# Altium schematic sheet ESP32_Relay_X4_Modbus(303E32DC411).SchDoc
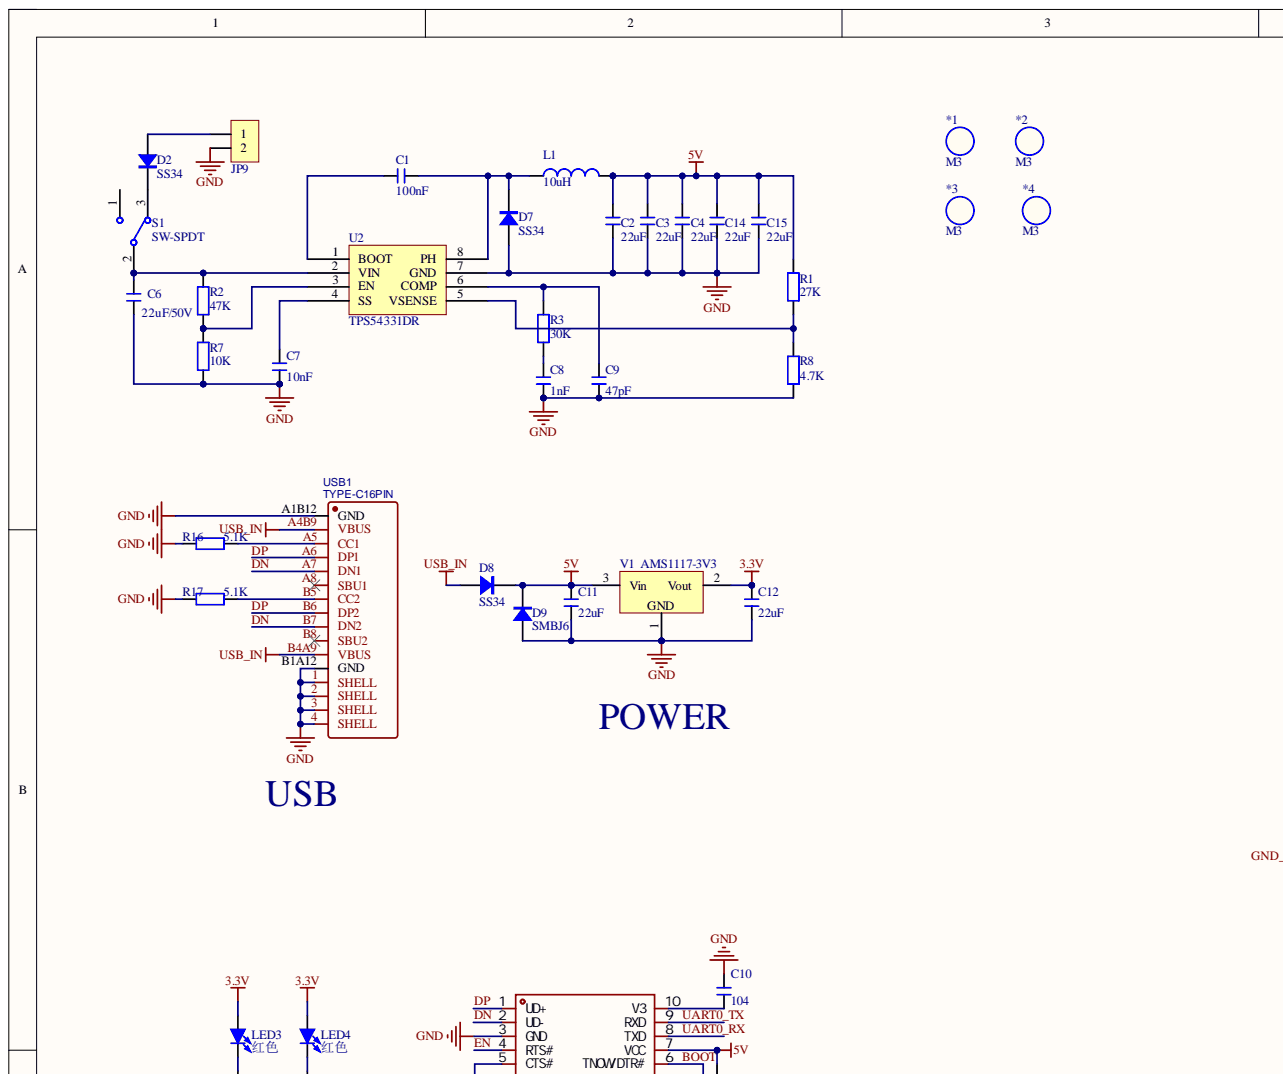
[diagram p0: ESP32_Relay_X4_Modbus(303E32DC411).SchDoc, top left]
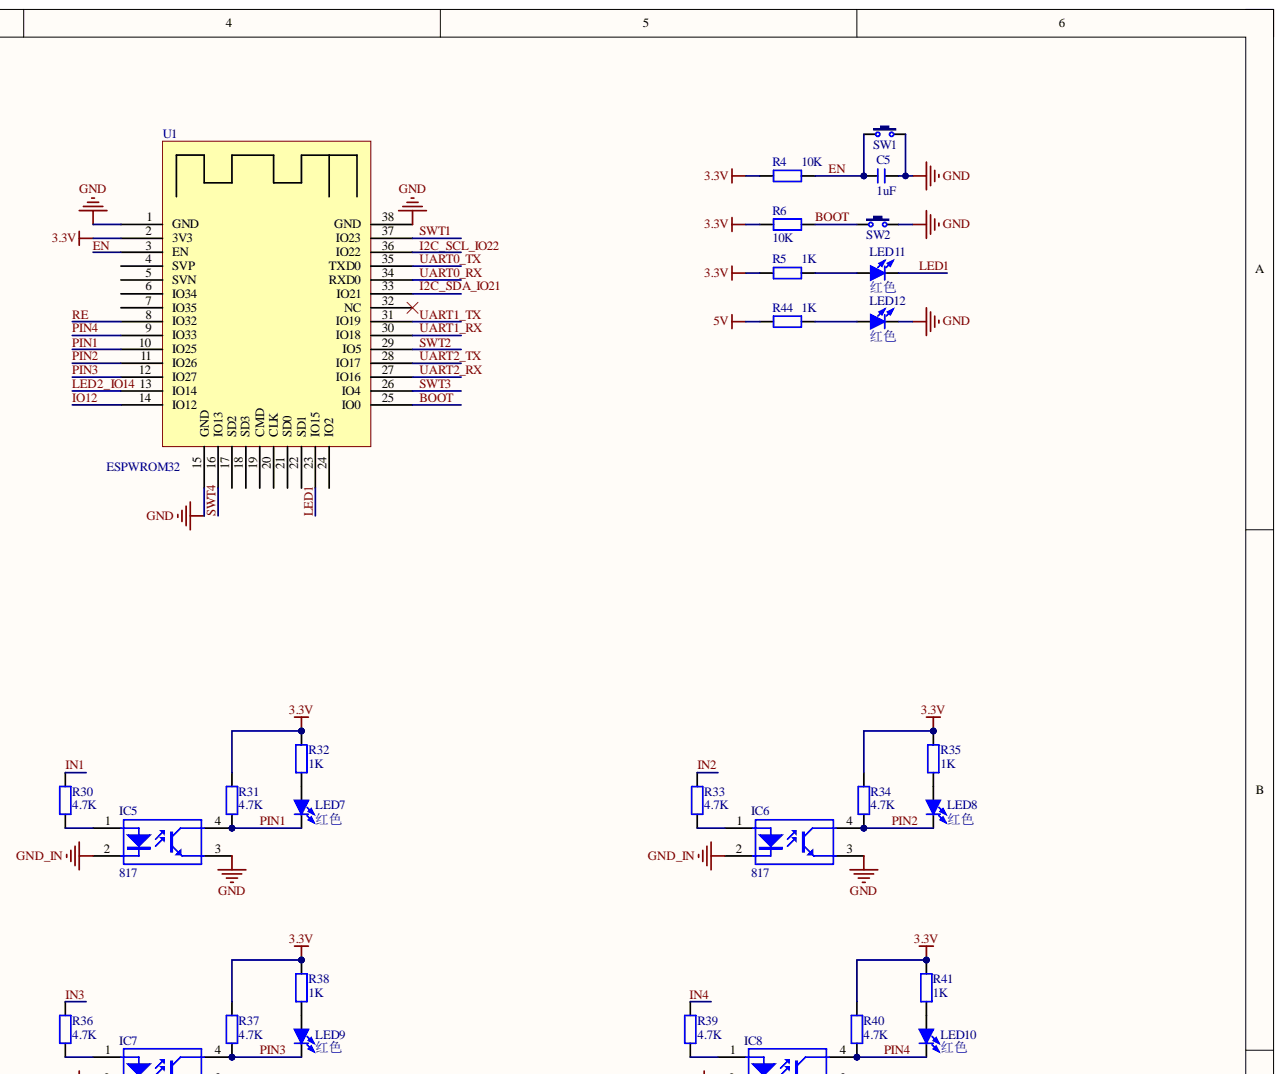
[diagram p1: ESP32_Relay_X4_Modbus(303E32DC411).SchDoc, top right]
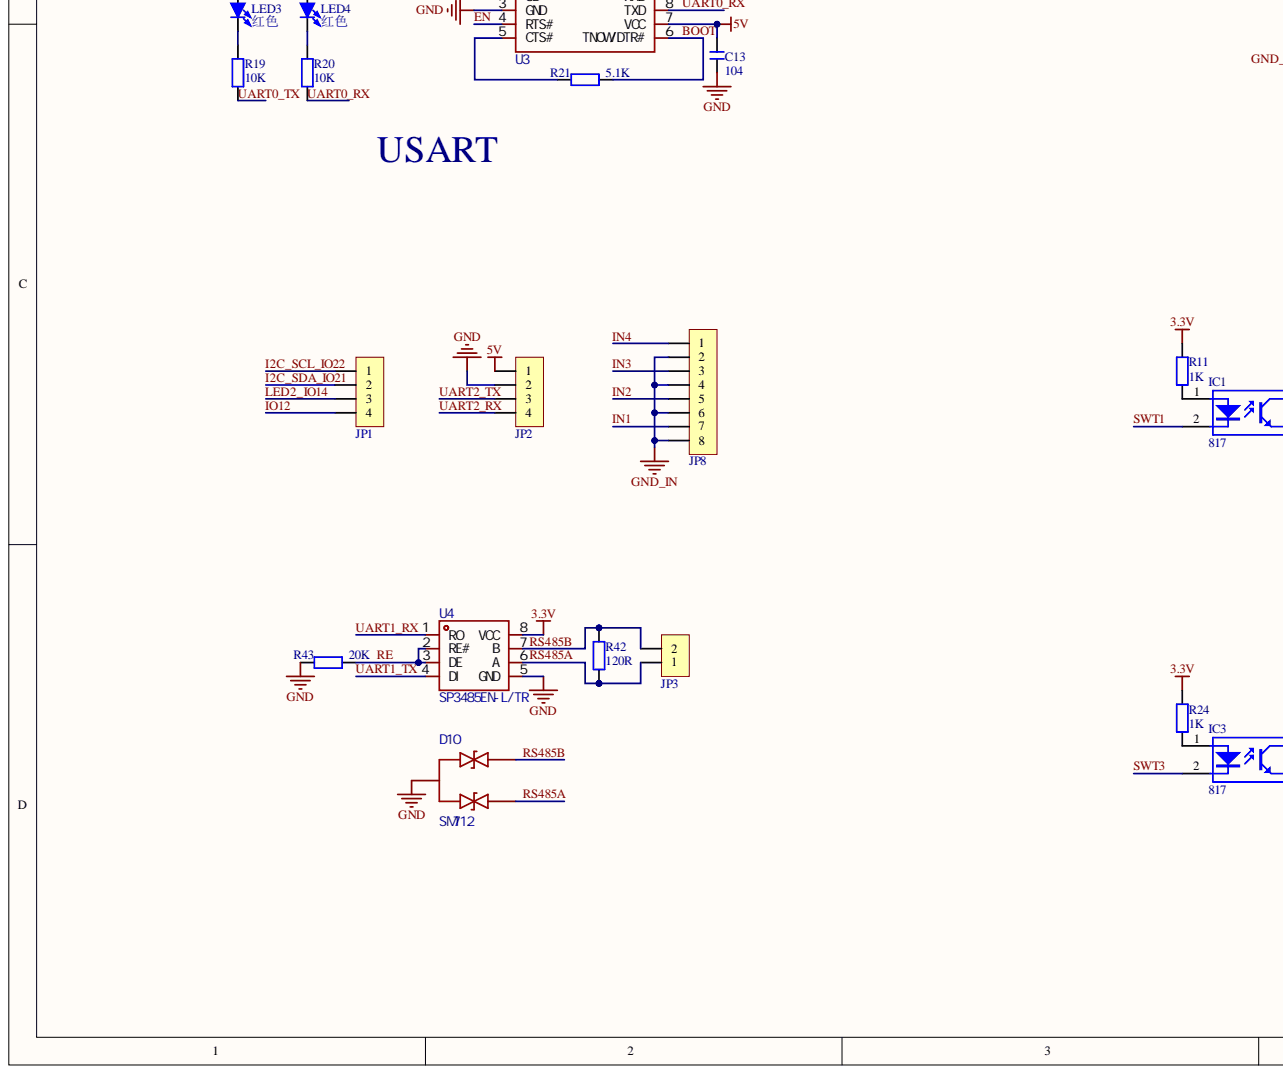
[diagram p2: ESP32_Relay_X4_Modbus(303E32DC411).SchDoc, bottom left]
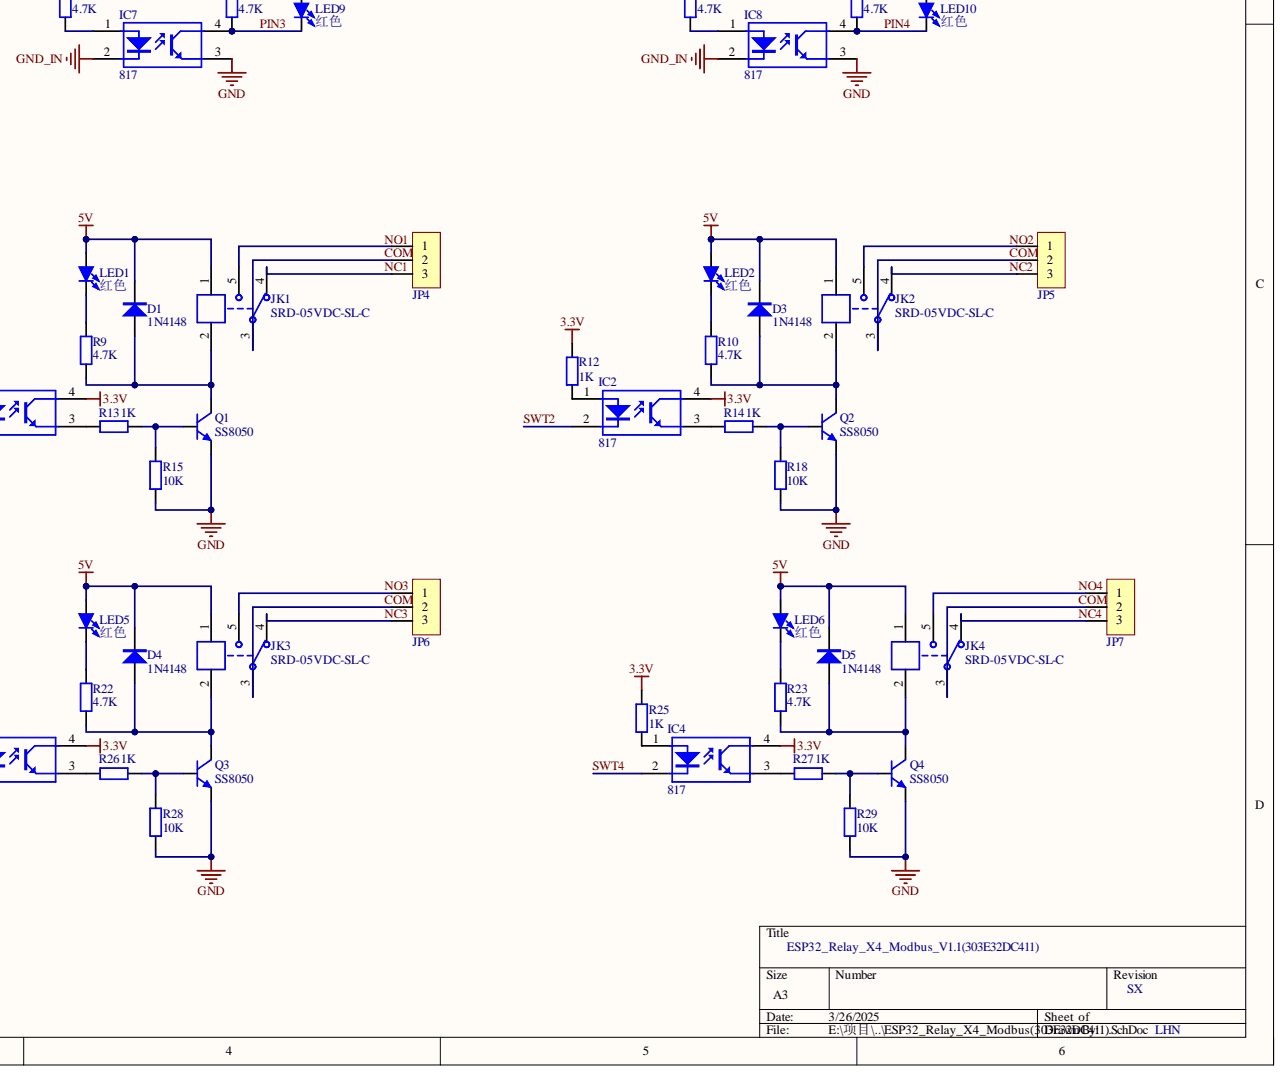
[diagram p3: ESP32_Relay_X4_Modbus(303E32DC411).SchDoc, bottom right]

## Parts
*1  M3  [M3 125X200]
*2  M3  [M3 125X200]
*3  M3  [M3 125X200]
*4  M3  [M3 125X200]
C1  100nF  [C 0603_L]
C2  22uF  [C 0805_L]
C3  22uF  [C 0805_L]
C4  22uF  [C 0805_L]
C5  1uF  [C 0603_L]
C6  22uF/50V  [C 0805_L]
C7  10nF  [C 0603_L]
C8  1nF  [C 0603_L]
C9  47pF  [C 0603_L]
C10  104  [C 0603_L]
C11  22uF  [C 0805_L]
C12  22uF  [C 0805_L]
C13  104  [C 0603_L]
C14  22uF  [C 0805_L]
C15  22uF  [C 0805_L]
D1  1N4148  [MINI_MELF (LL34)]
D2  SS34  [SMA]
D3  1N4148  [MINI_MELF (LL34)]
D4  1N4148  [MINI_MELF (LL34)]
D5  1N4148  [MINI_MELF (LL34)]
D7  SS34  [SMA]
D8  SS34  [SMA]
D9  SMBJ6  [SMA]
D10  SM712  [SOT-23-3_L2.9-W1.3-P1.90-LS2.4-BR]
IC1  817  [SOP4-W2.54_N]
IC2  817  [SOP4-W2.54_N]
IC3  817  [SOP4-W2.54_N]
IC4  817  [SOP4-W2.54_N]
IC5  817  [SOP4-W2.54_N]
IC6  817  [SOP4-W2.54_N]
IC7  817  [SOP4-W2.54_N]
IC8  817  [SOP4-W2.54_N]
JK1  SRD-05VDC-SL-C  [SRD-C_B]
JK2  SRD-05VDC-SL-C  [SRD-C_B]
JK3  SRD-05VDC-SL-C  [SRD-C_B]
JK4  SRD-05VDC-SL-C  [SRD-C_B]
JP1  HDR-1X4  [HDR2.54-LI-4P]  (value not drawn)
JP2  HDR-1X4  [HDR2.54-LI-4P]  (value not drawn)
JP3  HDR-1X2  [KF128-3.81-2P]  (value not drawn)
JP4  HDR-1X3  [KF128-3.81-3P]  (value not drawn)
JP5  HDR-1X3  [KF128-3.81-3P]  (value not drawn)
JP6  HDR-1X3  [KF128-3.81-3P]  (value not drawn)
JP7  HDR-1X3  [KF128-3.81-3P]  (value not drawn)
JP8  HDR-1X8  [KF128-3.81-8P]  (value not drawn)
JP9  HDR-1X2  [KF128-3.81-2P]  (value not drawn)
L1  value 10uH  [IND-SMD_L7.1-W6.6]  (hidden comment: MWSA0603S-100MT)
LED1  红色  [LED 0603R]
LED2  红色  [LED 0603R]
LED3  红色  [LED 0603R]
LED4  红色  [LED 0603R]
LED5  红色  [LED 0603R]
LED6  红色  [LED 0603R]
LED7  红色  [LED 0603R]
LED8  红色  [LED 0603R]
LED9  红色  [LED 0603R]
LED10  红色  [LED 0603R]
LED11  红色  [LED 0603R]
LED12  红色  [LED 0603R]
Q1  SS8050  [SOT23-3N]
Q2  SS8050  [SOT23-3N]
Q3  SS8050  [SOT23-3N]
Q4  SS8050  [SOT23-3N]
R1  27K  [R 0603_L]
R2  47K  [R 0603_L]
R3  30K  [R 0603_L]
R4  10K  [R 0603_L]
R5  1K  [R 0603_L]
R6  10K  [R 0603_L]
R7  10K  [R 0603_L]
R8  4.7K  [R 0603_L]
R9  4.7K  [R 0603_L]
R10  4.7K  [R 0603_L]
R11  1K  [R 0603_L]
R12  1K  [R 0603_L]
R13  1K  [R 0603_L]  (value not drawn)
R14  1K  [R 0603_L]  (value not drawn)
R15  10K  [R 0603_L]
R16  5.1K  [R 0603_L]
R17  5.1K  [R 0603_L]
R18  10K  [R 0603_L]
R19  10K  [R 0603_L]
R20  10K  [R 0603_L]
R21  5.1K  [R 0603_L]
R22  4.7K  [R 0603_L]
R23  4.7K  [R 0603_L]
R24  1K  [R 0603_L]
R25  1K  [R 0603_L]
R26  1K  [R 0603_L]  (value not drawn)
R27  1K  [R 0603_L]  (value not drawn)
R28  10K  [R 0603_L]
R29  10K  [R 0603_L]
R30  4.7K  [R 0603_L]
R31  4.7K  [R 0603_L]
R32  1K  [R 0603_L]
R33  4.7K  [R 0603_L]
R34  4.7K  [R 0603_L]
R35  1K  [R 0603_L]
R36  4.7K  [R 0603_L]
R37  4.7K  [R 0603_L]
R38  1K  [R 0603_L]
R39  4.7K  [R 0603_L]
R40  4.7K  [R 0603_L]
R41  1K  [R 0603_L]
R42  120R  [R 0603_L]
R43  20K  [R 0603_L]
R44  1K  [R 0603_L]
S1  SW-SPDT  [sw]
SW1  3x6x5  [SW-SMD_L3.9-W3.0-P4.45]  (value not drawn)
SW2  3x6x5  [SW-SMD_L3.9-W3.0-P4.45]  (value not drawn)
U1  ESPWROM32  [ESP32]
U2  TPS54331DR  [SOIC-8_150mil]
U3  CH340X  [MSOP-10_L3.0-W3.0-P0.50-LS5.0-BL]  (value not drawn)
U4  SP3485EN-L/TR  Supply Voltage: 3.3V  [SOIC-8_L5.0-W4.0-P1.27-LS6.0-BL]
USB1  TYPE-C16PIN  [USB-C-SMD_TYPE-C16PIN]
V1  AMS1117-3V3  [SOT223_N]

## Nets
3.3V: C12.1 IC1.4 IC2.4 IC3.4 IC4.4 LED3.1 LED4.1 R4.1 R5.1 R6.2 R11.1 R12.1 R24.1 R25.1 R31.1 R32.1 R34.1 R35.1 R37.1 R38.1 R40.1 R41.1 U1.2(3V3) U4.8(VCC) V1.2
5V: C2.2 C3.2 C4.2 C11.1 C13.2 C14.2 C15.2 D1.2 D3.2 D4.2 D5.2 D8.2 D9.2 JK1.1 JK2.1 JK3.1 JK4.1 JP2.1 L1.2 LED1.1 LED2.1 LED5.1 LED6.1 R1.2 R44.1 U3.7(VCC) V1.3
GND: C2.1 C3.1 C4.1 C5.1 C6.1 C7.2 C8.2 C9.2 C10.1 C11.2 C12.2 C13.1 C14.1 C15.1 D7.1 D9.1 D10.3 IC5.3 IC6.3 IC7.3 IC8.3 JP2.2 JP9.2 LED12.2 Q1.2 Q2.2 Q3.2 Q4.2 R7.1 R8.1 R15.2 R16.1 R17.1 R18.2 R28.2 R29.2 R43.2 SW1.2 SW2.2 U1.1(GND) U1.15(GND) U1.38(GND) U2.7(GND) U3.3(GND) U4.5(GND) USB1.1(SHELL) USB1.2(SHELL) USB1.3(SHELL) USB1.4(SHELL) USB1.A1B12(GND) USB1.B1A12(GND) V1.1
GND_IN: IC5.2 IC6.2 IC7.2 IC8.2 JP8.2 JP8.4 JP8.6 JP8.8
USB_IN: D8.1 USB1.A4B9(VBUS) USB1.B4A9(VBUS)
BOOT: R6.1 R21.1 SW2.1 U1.25(IO0) U3.6(TNOW/DTR#)
COM1: JK1.3 JP4.2
COM2: JK2.3 JP5.2
COM3: JK3.3 JP6.2
COM4: JK4.3 JP7.2
DN: U3.2(UD-) USB1.A7(DN1) USB1.B7(DN2)
DP: U3.1(UD+) USB1.A6(DP1) USB1.B6(DP2)
EN: C5.2 R4.2 SW1.1 U1.3(EN) U3.4(RTS#)
I2C_SCL_IO22: JP1.1 U1.36(IO22)
I2C_SDA_IO21: JP1.2 U1.33(IO21)
IN1: JP8.7 R30.1
IN2: JP8.5 R33.1
IN3: JP8.3 R36.1
IN4: JP8.1 R39.1
IO12: JP1.4 U1.14(IO12)
LED1: LED11.2 U1.23(IO15)
LED2_IO14: JP1.3 U1.13(IO14)
NC1: JK1.4 JP4.3
NC2: JK2.4 JP5.3
NC3: JK3.4 JP6.3
NC4: JK4.4 JP7.3
NO1: JK1.5 JP4.1
NO2: JK2.5 JP5.1
NO3: JK3.5 JP6.1
NO4: JK4.5 JP7.1
PIN1: IC5.4 LED7.2 R31.2 U1.10(IO25)
PIN2: IC6.4 LED8.2 R34.2 U1.11(IO26)
PIN3: IC7.4 LED9.2 R37.2 U1.12(IO27)
PIN4: IC8.4 LED10.2 R40.2 U1.9(IO33)
RE: R43.1 U1.8(IO32) U4.2(RE#) U4.3(DE)
RS485A: D10.1 JP3.1 R42.2 U4.6(A)
RS485B: D10.2 JP3.2 R42.1 U4.7(B)
SWT1: IC1.2 U1.37(IO23)
SWT2: IC2.2 U1.29(IO5)
SWT3: IC3.2 U1.26(IO4)
SWT4: IC4.2 U1.16(IO13)
UART0_RX: R20.1 U1.34(RXD0) U3.8(TXD)
UART0_TX: R19.1 U1.35(TXD0) U3.9(RXD)
UART1_RX: U1.30(IO18) U4.1(RO)
UART1_TX: U1.31(IO19) U4.4(DI)
UART2_RX: JP2.4 U1.27(IO16)
UART2_TX: JP2.3 U1.28(IO17)
NetC1_1: C1.1 U2.1(BOOT)
NetC1_2: C1.2 D7.2 L1.1 U2.8(PH)
NetC6_2: C6.2 R2.2 S1.2 U2.2(VIN)
NetC7_1: C7.1 U2.4(SS)
NetC8_1: C8.1 R3.1
NetC9_1: C9.1 R3.2 U2.6(COMP)
NetC10_2: C10.2 U3.10(V3)
NetD1_1: D1.1 JK1.2 Q1.3 R9.2
NetD2_1: D2.1 JP9.1
NetD2_2: D2.2 S1.3
NetD3_1: D3.1 JK2.2 Q2.3 R10.2
NetD4_1: D4.1 JK3.2 Q3.3 R22.2
NetD5_1: D5.1 JK4.2 Q4.3 R23.2
NetIC1_1: IC1.1 R11.2
NetIC1_3: IC1.3 R13.1
NetIC2_1: IC2.1 R12.2
NetIC2_3: IC2.3 R14.1
NetIC3_1: IC3.1 R24.2
NetIC3_3: IC3.3 R26.1
NetIC4_1: IC4.1 R25.2
NetIC4_3: IC4.3 R27.1
NetIC5_1: IC5.1 R30.2
NetIC6_1: IC6.1 R33.2
NetIC7_1: IC7.1 R36.2
NetIC8_1: IC8.1 R39.2
NetLED1_2: LED1.2 R9.1
NetLED2_2: LED2.2 R10.1
NetLED3_2: LED3.2 R19.2
NetLED4_2: LED4.2 R20.2
NetLED5_2: LED5.2 R22.1
NetLED6_2: LED6.2 R23.1
NetLED7_1: LED7.1 R32.2
NetLED8_1: LED8.1 R35.2
NetLED9_1: LED9.1 R38.2
NetLED10_1: LED10.1 R41.2
NetLED11_1: LED11.1 R5.2
NetLED12_1: LED12.1 R44.2
NetQ1_1: Q1.1 R13.2 R15.1
NetQ2_1: Q2.1 R14.2 R18.1
NetQ3_1: Q3.1 R26.2 R28.1
NetQ4_1: Q4.1 R27.2 R29.1
NetR1_1: R1.1 R8.2 U2.5(VSENSE)
NetR2_1: R2.1 R7.2 U2.3(EN)
NetR16_2: R16.2 USB1.A5(CC1)
NetR17_2: R17.2 USB1.B5(CC2)
NetR21_2: R21.2 U3.5(CTS#)

Not connected: S1 1; U1 4(SVP) 5(SVN) 6(IO34) 7(IO35) 17(SD2) 18(SD3) 19(CMD) 20(CLK) 21(SD0) 22(SD1) 24(IO2) 32(NC); USB1 A8(SBU1) B8(SBU2)

## Library data of the parts
*1-*4 = M3: 铜柱孔; 版权归属: 源创客; BOM名称: 铜柱孔; Component Kind: Standard; Library Name: 15.1 - 未分类器件.SchLib; 学习资源: www.ooocke.com
C1-C15 = C: 无极性贴片电容; 材质: X7R; 版权归属: 源创客; BOM名称: 无极性贴片电容; Component Kind: Standard; Library Name: 3.1   - 电阻-电容-电感.SchLib; 学习资源: www.ooocke.com
D1 D3-D5 = 1N4148: 高速开关二极管; 版权归属: 源创客; BOM名称: 高速开关二极管; Component Kind: Standard; Library Name: 8.1   - 二极管-整流桥.SchLib; 学习资源: www.ooocke.com
D2 D7-D9 = SS24: 肖特基二极管; 属性: 40V/2A; 版权归属: 源创客; BOM名称: 肖特基二极管; Component Kind: Standard; Library Name: 8.1   - 二极管-整流桥.SchLib; 学习资源: www.ooocke.com
D10 = SM712_C521963: Manufacturer: TECH PUBLIC(台舟); Add into BOM: yes; Breakdown Voltage: 7.5V；13.3V; level of protection: IEC 61000-4-2; Maximum Clamping Voltage: 15V；25V; Number of Lines: 双路; Peak Pulse Current (Ipp): 7A; Peak Pulse Power Dissipation (Ppp): 150W; polarity: 双向; Reverse Leakage Current (Ir): 500nA；10nA; Reverse Stand-Off Voltage (Vrwm): 12V；7V; Type: ESD; Component Kind: Standard; Convert to PCB: yes; Datasheet: https://atta.szlcsc.com/upload/public/pdf/source/20210810/E76C7C1824B0CB2A5B8963B3ABCE2DCE.pdf; Device: SM712_C521963; JLCPCB Part Class: 扩展库; LCSC Part Name: SM712; Manufacturer Part: SM712; Operating Temperature: -55℃~+125℃; PCB3D: SOT-23-3P_L2.9-W1.3-H1.0-LS2.4-P0.95; Supplier: LCSC; Supplier Footprint: SOT-23; Supplier Part: C521963; Symbol: SM712_C521963
IC1-IC8 = 817: 线性光耦; 版权归属: 源创客; BOM名称: 线性光耦; Component Kind: Standard; Library Name: 11.1 - 传感元件.SchLib; 学习资源: www.ooocke.com
JK1-JK4 = SRD-05VDC-SL-C: 5V一组转换; BOM名称: 5V继电器; 版权归属: 源创客; Component Kind: Standard; Library Name: 7.1   - 继电器.SchLib; 学习资源: www.ooocke.com
JP1 JP2 = Header 4: 4P接插件; 版权归属: 源创客; BOM名称: 4P接插件; Component Kind: Standard; Library Name: 4.6   - 接插件-脚距2.54.SchLib; 学习资源: www.ooocke.com
JP3 JP9 = Header 2: 2P接插件; 版权归属: 源创客; BOM名称: 2P接插件; Component Kind: Standard; Library Name: 4.8   - 接插件-脚距3.81.SchLib; 学习资源: www.ooocke.com
JP4-JP7 = Header 3: 3P接插件; 版权归属: 源创客; BOM名称: 3P接插件; Component Kind: Standard; Library Name: 4.8   - 接插件-脚距3.81.SchLib; 学习资源: www.ooocke.com
JP8 = Header 8: 8P接插件; 版权归属: 源创客; BOM名称: 8P接插件; Component Kind: Standard; Library Name: 4.8   - 接插件-脚距3.81.SchLib; 学习资源: www.ooocke.com
L1 = Inductor: Code_IEC: 1005; Code_JEITA: 0402; Component Kind: Standard; Description: Inductor; LatestRevisionDate: 29-May-2009; LatestRevisionNote: IPC-7351 Footprint Added.; Library Name: Miscellaneous Devices.IntLib; PackageDescription: Chip Inductor; PackageReference: 0402-A; Published: 8-Jun-2000; Signal Integrity: Inductor; Simulation: INDUCTOR; Publisher: Altium Limited
LED1-LED12 = LED-SMD: 贴片LED; 版权归属: 源创客; BOM名称: 贴片LED; Component Kind: Standard; Library Name: 9.1   - LED.SchLib; 学习资源: www.ooocke.com
Q1-Q4 = 8050-SMD: 高频放大-NPN型; 属性: 40V 1.5A 1W 100MHZ; 版权归属: 源创客; BOM名称: 高频放大-NPN型; Component Kind: Standard; Library Name: 6.2   - 三极管.SchLib; 学习资源: www.ooocke.com
R1-R44 = R: 贴片电阻; 版权归属: 源创客; BOM名称: 贴片电阻; Component Kind: Standard; Library Name: 3.1   - 电阻-电容-电感.SchLib; 学习资源: www.ooocke.com
S1 = SW-SPDT: SPDT Subminiature Toggle Switch, Right Angle Mounting, Vertical Actuation; Component Kind: Standard; ComponentLink1Description: Manufacturer Link; ComponentLink1URL: http://www.apem.com; DatasheetVersion: 05-Dec-2000; LatestRevisionDate: 17-Dec-2003; LatestRevisionNote: Schematic revised, PCB Footprint replaced.; Library Name: ESP32S3-AI_V1.1(303ESP32AI1).SCHLIB; PackageReference: TL36WW15050; Published: 24-Mar-1999; Publisher: Altium Limited
SW1 SW2 = TSW 3x6: 3x6轻触开关; 版权归属: 源创客; BOM名称: 3x6轻触开关; Component Kind: Standard; Library Name: 5.1   - 按键-开关.SchLib; 学习资源: www.ooocke.com
U3 = CH340X: Manufacturer: WCH(南京沁恒); Add into BOM: yes; Component Kind: Standard; Convert to PCB: yes; Datasheet: https://atta.szlcsc.com/upload/public/pdf/source/20230530/6E401E9554E9F5311886CC8B3F89B62E.pdf; Device: CH340X; JLCPCB Part Class: 扩展库; LCSC Part Name: CH340X; Library Name: ESP32S3-AI(303BATPOW01).SCHLIB; Manufacturer Part: CH340X; Supplier: LCSC; Supplier Footprint: MSOP-10; Supplier Part: C3035748; Symbol: CH340X
U4 = SP3485EN-L/TR: Manufacturer: MaxLinear(迈凌); Add into BOM: yes; Data Rate: 10Mbps; Number of Driver: 1; Types: 收发器; Component Kind: Standard; Convert to PCB: yes; Datasheet: https://atta.szlcsc.com/upload/public/pdf/source/20170601/1496285796963.pdf; Device: SP3485EN-L/TR; JLCPCB Part Class: 基础库; LCSC Part Name: SP3485 +3.3V低功耗半双工RS-485收发器，数据速率10Mbps; Manufacturer Part: SP3485EN-L/TR; Number of receiver: 1; Operating Temperature: -40℃~+85℃; PCB3D: SOIC-8_L4.9-W3.9-H1.7-LS6.0-P1.27; Supplier: LCSC; Supplier Footprint: SOIC-8; Supplier Part: C8963; Symbol: SP3485EN-L/TR
USB1 = TYPE-C16PIN: Manufacturer: SHOU HAN; Contributor: LCSC; Component Kind: Standard; Manufacturer Part: TYPE-C16PIN; spicePre: U; spiceSymbolName: TYPE-C16PIN; Supplier: LCSC; Supplier Part: C393939
V1 = AMS1117: 三端稳压芯片; BOM名称: 稳压芯片; 版权归属: 源创客; Component Kind: Standard; Library Name: 1.3   - 电源类芯片.SchLib; 学习资源: www.ooocke.com
U1 = ESPWROM32: Component Kind: Standard; Library Name: Schlib1.SchLib
U2 = TPS54331DR: Component Kind: Standard; Library Name: CherryPi-PC-V3S_DZ.SCHLIB
